# Altium schematic sheet LVDS_IFCB.SchDoc
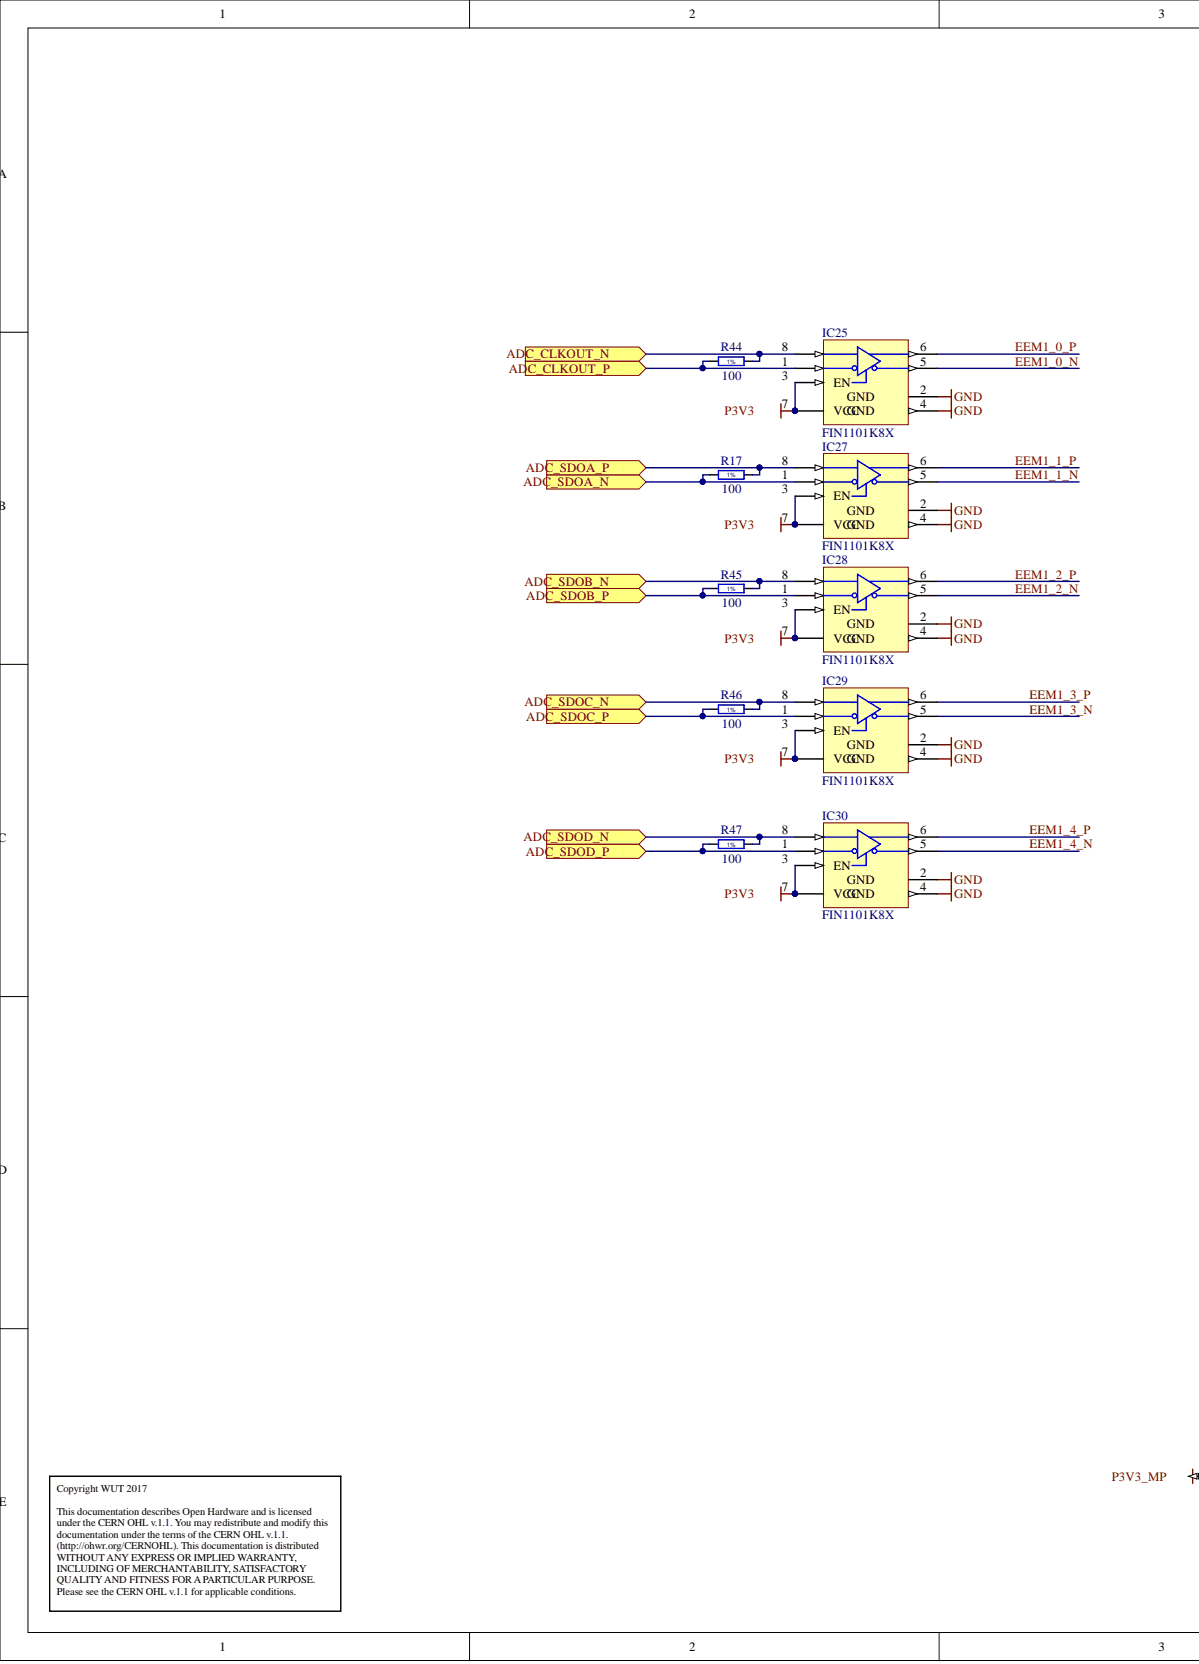
[diagram p0: LVDS_IFCB.SchDoc, left]
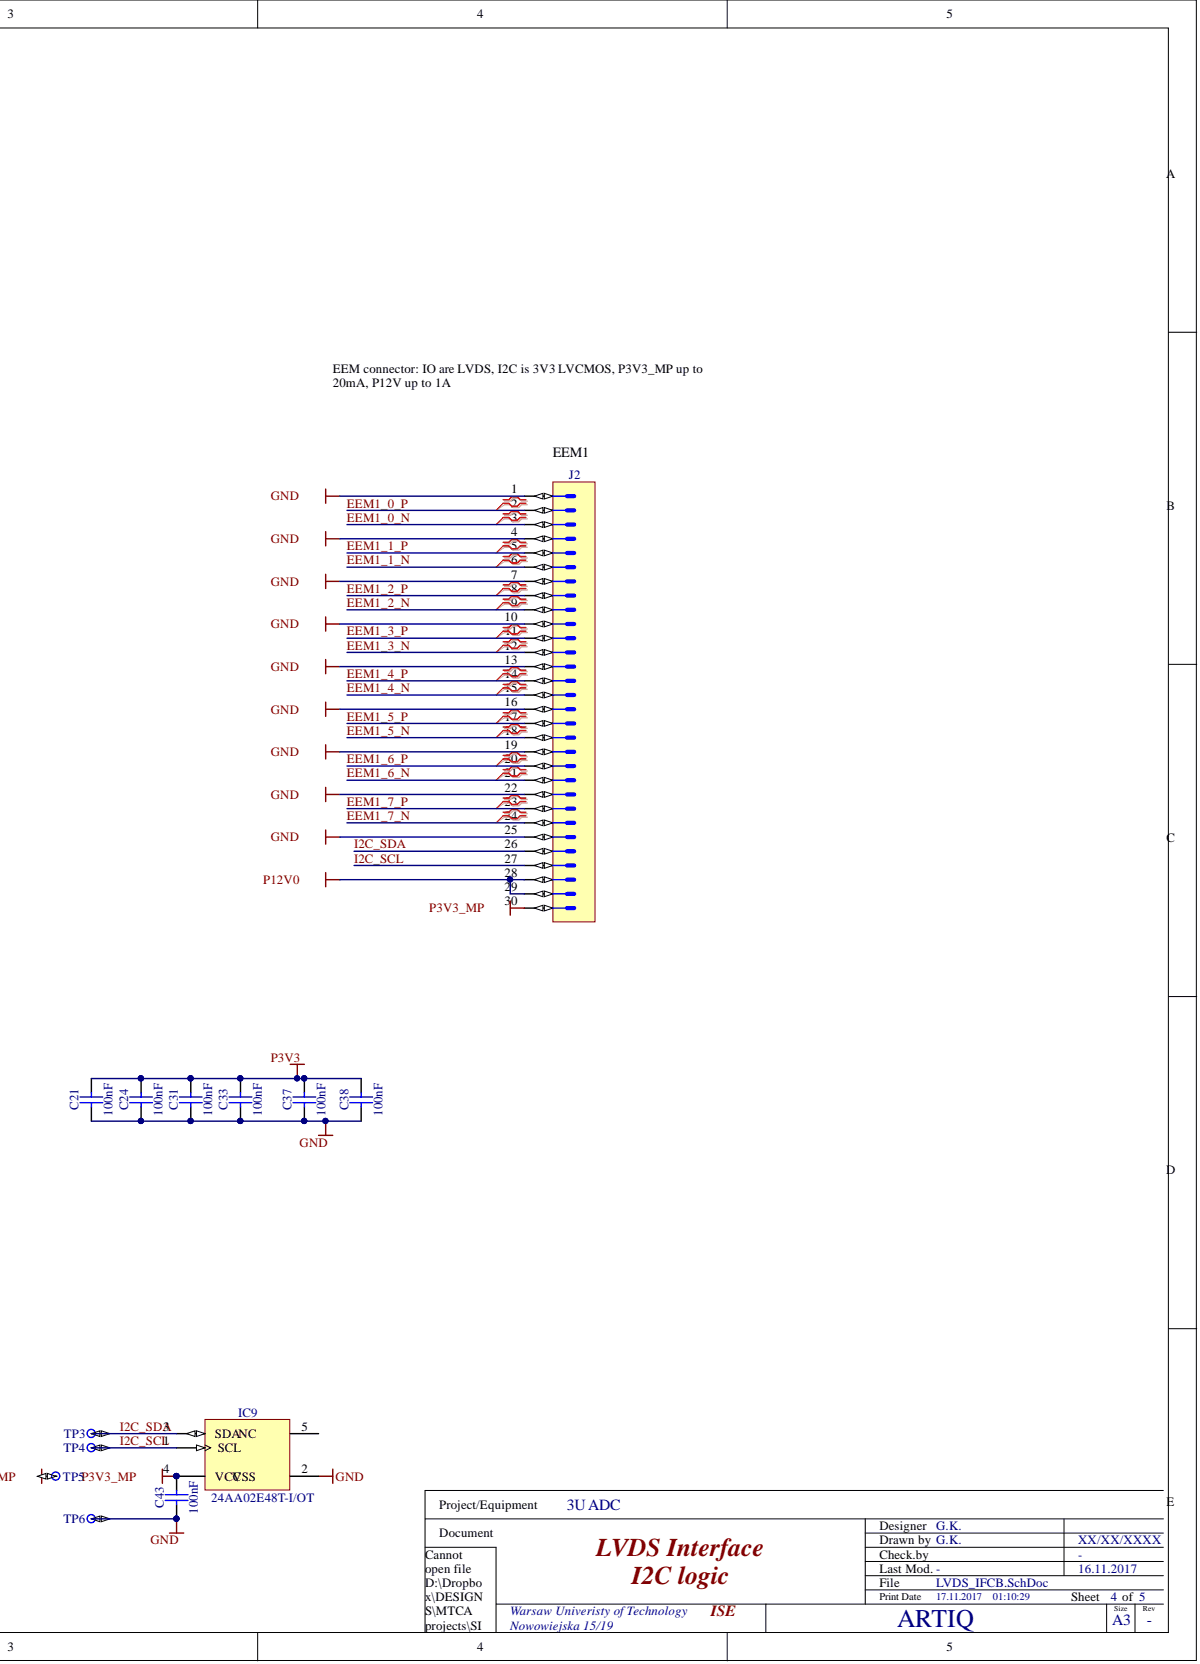
[diagram p1: LVDS_IFCB.SchDoc, right]

## Parts
C21  100nF  [CAPC1005X55N]
C24  100nF  [CAPC1005X55N]
C31  100nF  [CAPC1005X55N]
C33  100nF  [CAPC1005X55N]
C37  100nF  [CAPC1005X55N]
C38  100nF  [CAPC1005X55N]
C43  100nF  [CAPC1005X55N]
IC9  24AA02E48T-I/OT  [SOT95P280X145-5N]
IC25  FIN1101K8X  [SOP50P310X90-8N]
IC27  FIN1101K8X  [SOP50P310X90-8N]
IC28  FIN1101K8X  [SOP50P310X90-8N]
IC29  FIN1101K8X  [SOP50P310X90-8N]
IC30  FIN1101K8X  [SOP50P310X90-8N]
J2  Familly  [3M_N2530-6002RB]  (value not drawn)
R17  100  [RESC1005X40N]
R44  100  [RESC1005X40N]
R45  100  [RESC1005X40N]
R46  100  [RESC1005X40N]
R47  100  [RESC1005X40N]
TP3  Test Point  [TPS127]  (value not drawn)  (no BOM)
TP4  Test Point  [TPS127]  (value not drawn)  (no BOM)
TP5  Test Point  [TPS127]  (value not drawn)  (no BOM)
TP6  Test Point  [TPS127]  (value not drawn)  (no BOM)

## Ports
ADC_CLKOUT_N, ADC_CLKOUT_P, ADC_SDOA_N, ADC_SDOA_P, ADC_SDOB_N, ADC_SDOB_P, ADC_SDOC_N, ADC_SDOC_P, ADC_SDOD_N, ADC_SDOD_P

## Nets
GND: C21.1 C24.1 C31.1 C33.1 C37.1 C38.1 C43.1 IC9.2 IC25.2 IC25.4 IC27.2 IC27.4 IC28.2 IC28.4 IC29.2 IC29.4 IC30.2 IC30.4 J2.1 J2.4 J2.7 J2.10 J2.13 J2.16 J2.19 J2.22 J2.25 TP6.1
P3V3: C21.2 C24.2 C31.2 C33.2 C37.2 C38.2 IC25.3(EN) IC25.7(VCC) IC27.3(EN) IC27.7(VCC) IC28.3(EN) IC28.7(VCC) IC29.3(EN) IC29.7(VCC) IC30.3(EN) IC30.7(VCC)
P3V3_MP: C43.2 IC9.4(VCC) J2.30 TP5.1
P12V0: J2.28 J2.29
ADC_CLKOUT_N: IC25.8 R44.2 ; port ADC_CLKOUT_N
ADC_CLKOUT_P: IC25.1 R44.1 ; port ADC_CLKOUT_P
ADC_SDOA_N: IC27.1 R17.1 ; port ADC_SDOA_N
ADC_SDOA_P: IC27.8 R17.2 ; port ADC_SDOA_P
ADC_SDOB_N: IC28.8 R45.2 ; port ADC_SDOB_N
ADC_SDOB_P: IC28.1 R45.1 ; port ADC_SDOB_P
ADC_SDOC_N: IC29.8 R46.2 ; port ADC_SDOC_N
ADC_SDOC_P: IC29.1 R46.1 ; port ADC_SDOC_P
ADC_SDOD_N: IC30.8 R47.2 ; port ADC_SDOD_N
ADC_SDOD_P: IC30.1 R47.1 ; port ADC_SDOD_P
EEM1_0_N: IC25.5 J2.3
EEM1_0_P: IC25.6 J2.2
EEM1_1_N: IC27.5 J2.6
EEM1_1_P: IC27.6 J2.5
EEM1_2_N: IC28.5 J2.9
EEM1_2_P: IC28.6 J2.8
EEM1_3_N: IC29.5 J2.12
EEM1_3_P: IC29.6 J2.11
EEM1_4_N: IC30.5 J2.15
EEM1_4_P: IC30.6 J2.14
EEM1_5_N: J2.18
EEM1_5_P: J2.17
EEM1_6_N: J2.21
EEM1_6_P: J2.20
EEM1_7_N: J2.24
EEM1_7_P: J2.23
I2C_SCL: IC9.1(SCL) J2.27 TP4.1
I2C_SDA: IC9.3(SDA) J2.26 TP3.1

Not connected: IC9 5

## Library data of the parts
C21 C24 C31 C33 C37 C38 C43 = CC0402_100NF_16V_10%_X5R: Non-polarised capacitor; Manufacturer: GENERIC; Manufacturer1 Part Number: GRM155R61C104KA88D; Part Description: SMD Multilayer Chip Ceramic Capacitor; Database Table Name: Capacitors SMD; TC: X5R; Tolerance: ±10%; Voltage: 16V; Case: 0402; Component Kind: Standard; Component Type: Standard; CreateDate: 10.12.2013; HelpURL: \\cern.ch\dfs\Applications\Altium\Datasheets\CC0402_X5R_MURATA_GRM.pdf; LatestRevisionDate: 10.12.2013; Library Name: Capacitors.DbLib; Manufacturer1 ComponentHeight: 0.55mm; Manufacturer1 Example: MURATA; Manufacturer Part Number: CC0402_100NF_16V_10%_X5R; Mounted: Yes; Part Number: CC0402_100NF_16V_10%_X5R; Pin Count: 2; Sense: No; SMD: Yes; Socket: No; Status: None; Value: 100nF; Author: CERN DEM JLC
IC9 = 24AA02E48T-I/OT: 1.7V-5.5V, 2K I2C Serial EEPROM With EUI-48 Node Identity; Manufacturer: MICROCHIP TECHNOLOGY; Bonding: No; Database Table Name: Logic; PressFit: No; Case: SOT23-5; ComponentHeight: 1.45mm; Component Kind: Standard; Component Type: Standard; CreateDate: 31.03.2016; Device: 24AA02E48T-I/OT; HelpURL: \\cern.ch\dfs\Applications\Altium\Datasheets\24AA02E48T-I-OT.pdf; LatestRevisionDate: 31.03.2016; Library Name: ICs And Semiconductors.DbLib; Manufacturer Part Number: 24AA02E48T-I/OT; Mounted: Yes; PackageDescription: SOT, 0.95mm pitch; 5 pin, 1.60mm W X 2.90mm L X 1.45mm H body, IPC Medium Density; Part Description: 1.7V-5.5V, 2K I2C Serial EEPROM With EUI-48 Node Identity; Part Number: 24AA02E48T-I/OT; Pin Count: 5; Sense: No; SMD: Yes; Socket: No; Author: CERN DEM MR
IC25 IC27-IC30 = FIN1101K8X: LVDS Single Port High Speed Repeater; Manufacturer: FAIRCHILD SEMICONDUCTOR; Bonding: No; Database Table Name: Analog & Interface; PressFit: No; Case: TSSOP8; ComponentHeight: 0.8mm; Component Kind: Standard; Component Type: Standard; CreateDate: 08.11.2016; Device: FIN1101K8X; HelpURL: \\cern.ch\dfs\Applications\Altium\Datasheets\FIN1101K8X.pdf; LatestRevisionDate: 08.11.2016; Library Name: ICs And Semiconductors.DbLib; Manufacturer Part Number: FIN1101K8X; Mounted: Yes; PackageDescription: SOP, 0.50mm Pitch; 8 pin, 2.30mm W X 2.00mm L X 0.90mm H body, PC Medium Density; Part Description: LVDS Single Port High Speed Repeater; Part Number: FIN1101K8X; Pin Count: 8; Sense: No; SMD: Yes; Socket: No; Author: CERN DEM JLC
J2 = 3M_N2530-6002RB: Connector 30 Male; Part Description: 30 Contacts - Straight .100'' x .100'' Low Profile Four-Wall Header, 2500 Series; Database Table Name: 3M; PressFit: No; ComponentHeight: 10.03mm; Component Kind: Standard; Component Type: Standard; CreateDate: 11.02.2009; Family: Header 30; HelpURL: \\cern.ch\dfs\Applications\Altium\Datasheets\3M_N2530-6002RB.pdf; LatestRevisionDate: 11.08.2009; Library Name: Connectors.DbLib; Manufacturer: 3M; Manufacturer Part Number: N2530-6002RB; Mounted: Yes; Part Number: 3M_N2530-6002RB; Pin Count: 30; Sense: No; SMD: No; Socket: No; Status: None; Author: CERN DEM JLC
R17 R44-R47 = R0402_100R_1%_0.1W_100PPM: Resistor - 1%; Manufacturer: GENERIC; Manufacturer1 Part Number: ERJ2RKF1000X; Manufacturer Part Number: R0402_100R_1%_0.1W_100PPM_50V; Part Description: General Purpose Thick Film Chip Resistor; Database Table Name: Resistors SMD; Power: 0.1W; TC: ±100ppm/°C; Voltage: 50V; Case: 0402; Component Kind: Standard; Component Type: Standard; CreateDate: 12.12.2013; HelpURL: \\cern.ch\dfs\Applications\Altium\Datasheets\R0402_PANASONIC_ERJ2RK.pdf; LatestRevisionDate: 03.03.2015; Library Name: Resistors.DbLib; Manufacturer1 ComponentHeight: 0.4mm; Manufacturer1 Example: PANASONIC; Mounted: Yes; Part Number: R0402_100R_1%_0.1W_100PPM; Pin Count: 2; Sense: No; SMD: Yes; Socket: No; Status: Not recommended; Tolerance: ±1%; Value: 100; Author: CERN DEM JLC
TP3-TP6 = SMD_PAD_1.27X1.27MM: Part Description: 1.27mm x 1.27mm PCB Copper Area (SMD Test Point); Database Table Name: Pads; Mounted: No; ComponentHeight: 0mm; Component Type: Standard (No BOM); CreateDate: 12.01.2008; Description: Test Point; Family: Test Point; HelpURL: Undefined; LatestRevisionDate: 12.01.2008; Library Name: Eletro-mechanical.DbLib; PackageDescription: 1.27mm x 1.27mm PCB Copper Area (SMD Test Point); Part Number: SMD_PAD_1.27X1.27MM; Pin Count: 1; Sense: No; SMD: Yes; Socket: No; Status: None; Author: CERN DEM JLC
